# Altium schematic sheet IMU.SchDoc(IMU)
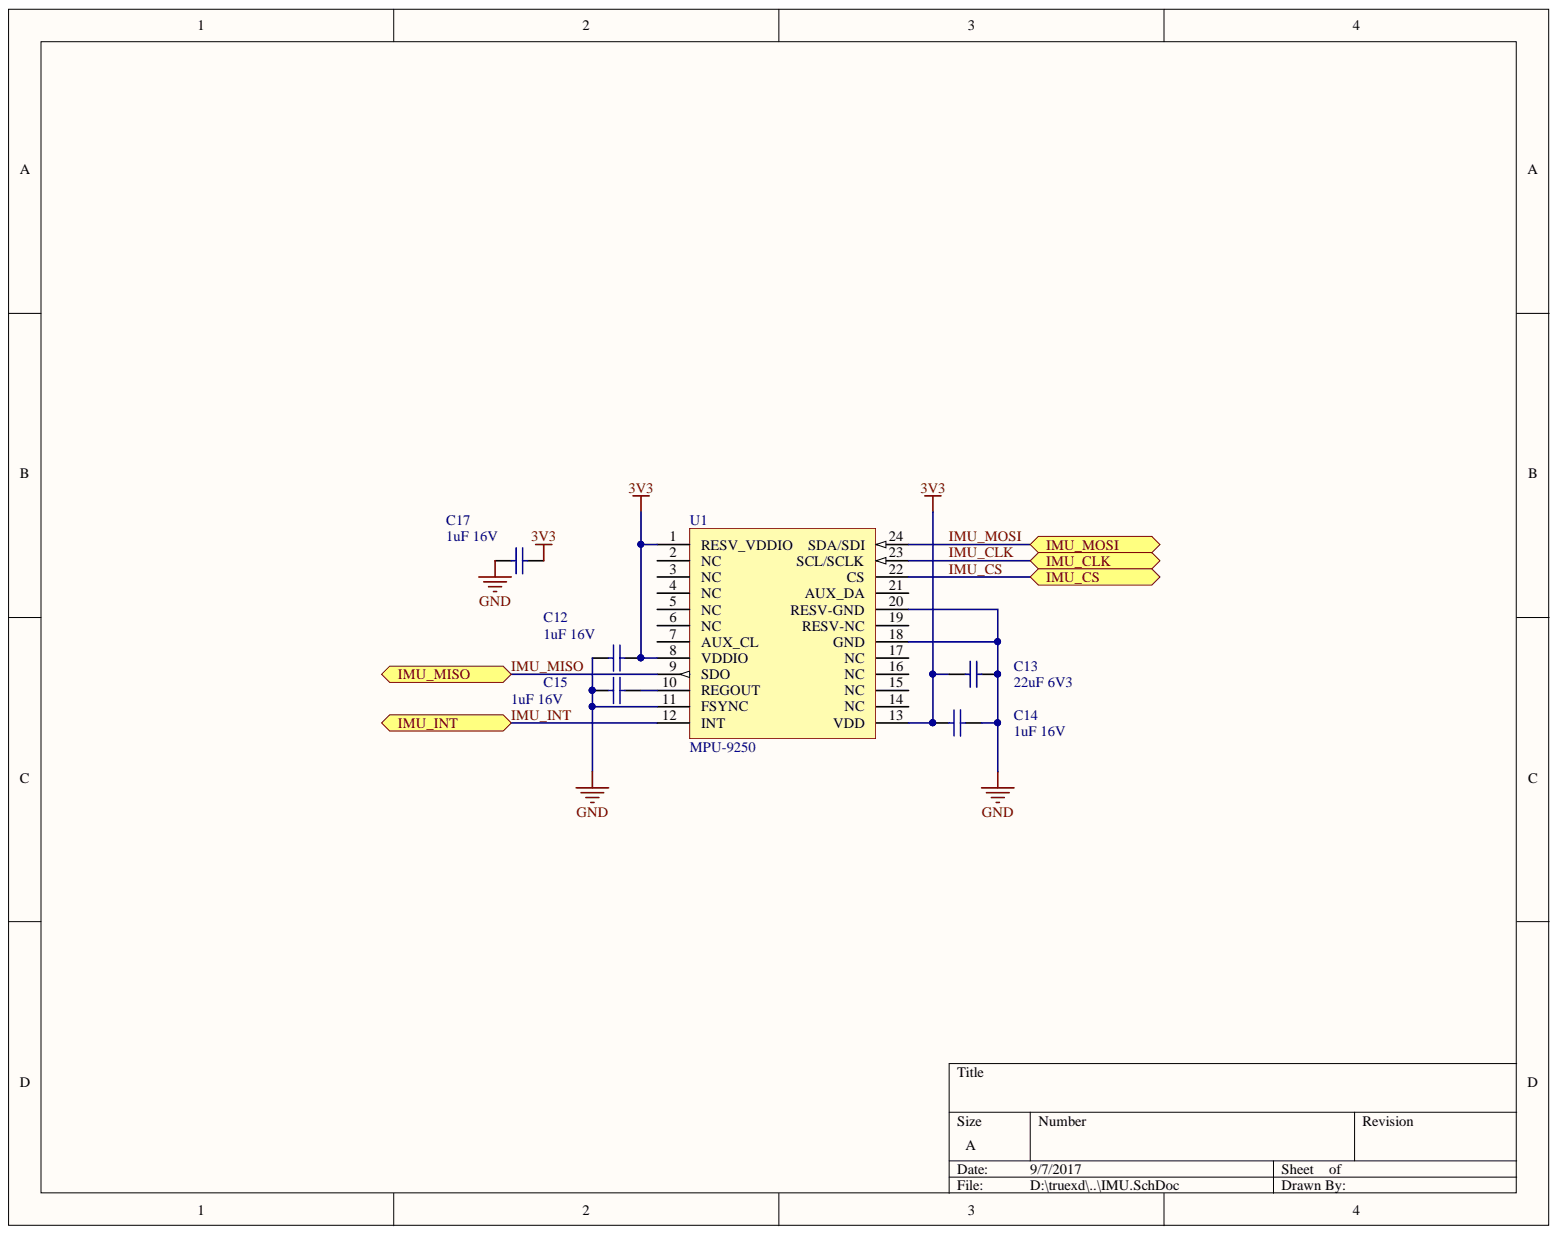
[diagram p0: IMU.SchDoc]

## Parts
C12  1uF 16V  [CAP-0306 - BARE]
C13  22uF 6V3  [2012[0805]]
C14  1uF 16V  [CAP-0306 - BARE]
C15  1uF 16V  [CAP-0306 - BARE]
C17  1uF 16V  [CAP-0306 - BARE]
U1  MPU-9250  [QFN24 3x3x1]

## Ports
IMU_CLK, IMU_CS, IMU_INT, IMU_MISO, IMU_MOSI

## Nets
3V3: C12.1 C13.2 C14.2 C17.1 U1.1(RESV_VDDIO) U1.8(VDDIO) U1.13(VDD)
GND: C12.2 C13.1 C14.1 C15.2 C17.2 U1.11(FSYNC) U1.18(GND) U1.20(RESV-GND)
IMU_CLK: U1.23(SCL/SCLK) ; port IMU_CLK
IMU_CS: U1.22(CS) ; port IMU_CS
IMU_INT: U1.12(INT) ; port IMU_INT
IMU_MISO: U1.9(SDO) ; port IMU_MISO
IMU_MOSI: U1.24(SDA/SDI) ; port IMU_MOSI
NetC15_1: C15.1 U1.10(REGOUT)

Not connected: U1 2(NC) 3(NC) 4(NC) 5(NC) 6(NC) 7(AUX_CL) 14(NC) 15(NC) 16(NC) 17(NC) 19(RESV-NC) 21(AUX_DA)

## Library data of the parts
C12 C14 C15 C17 = Cap Semi: CAP CER 1UF 16V X5R 0306; Part Number: C0816X5R1C105M050AC; Part No.: 445-4062-1-ND; Manufacturer: TDK Corporation; Value: 1.0uF; Do Not Load: IMU; Supplier: DigiKey; Simulation: CAP; Signal Integrity: Cap Semi; Primary Supplier Part #: 445-4062-1-ND; Primary Supplier: Digi-Key; PackageDescription: 0306 (0816M); Manufacturer Part #: C0816X5R1C105M050AC; Digikey: 445-13750-1-ND; Cost: .52; Component Kind: Standard; Library Name: rleg.IntLib
C13 = Cap Semi: CAP CER 22UF 10V 20% X5R 0805; Part Number: C0805C226M9PACTU; Manufacturer: TDK; Location: 3; Do Not Load: IMU; Simulation: CAP; Signal Integrity: Cap Semi; Manufacturer Part #: C0805C226M9PACTU; Library Name: Miscellaneous Devices.IntLib; Digikey: 399-4696-1-ND; Cost: 0.45; Component Kind: Standard
U1 = MPU-9250: GYRO/ACCEL/COMPASS/9-AXIS; Part No.: 1428-1019-1-ND; Manufacturer: TDK InvenSense; Do Not Load: IMU; Supplier: DigiKey; Part Number: MPU-9250; Manufacturer Part #: MPU-9250; Digikey: 1428-1019-1-ND; Cost: 10.63; Component Kind: Standard; Library Name: rleg.SchLib
